# Altium schematic sheet 08_HALF_BRIDGE.SchDoc(08_HALF_BRIDGE)
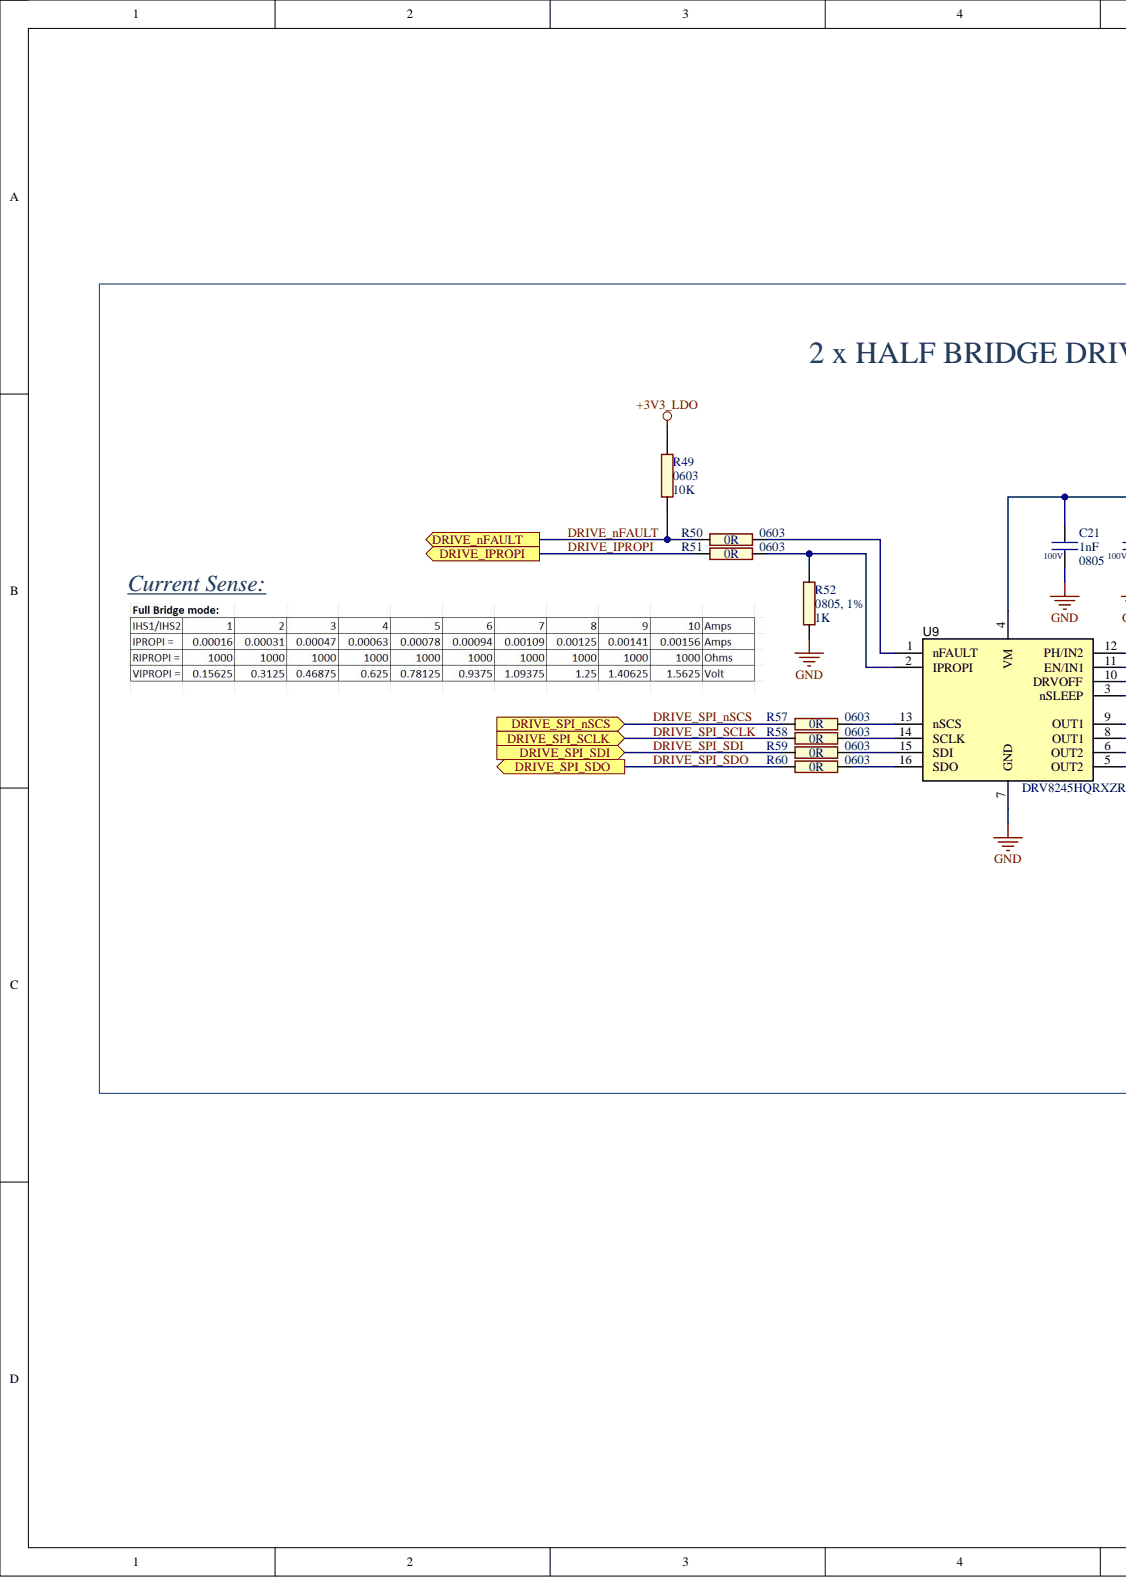
[diagram p0: 08_HALF_BRIDGE.SchDoc, left]
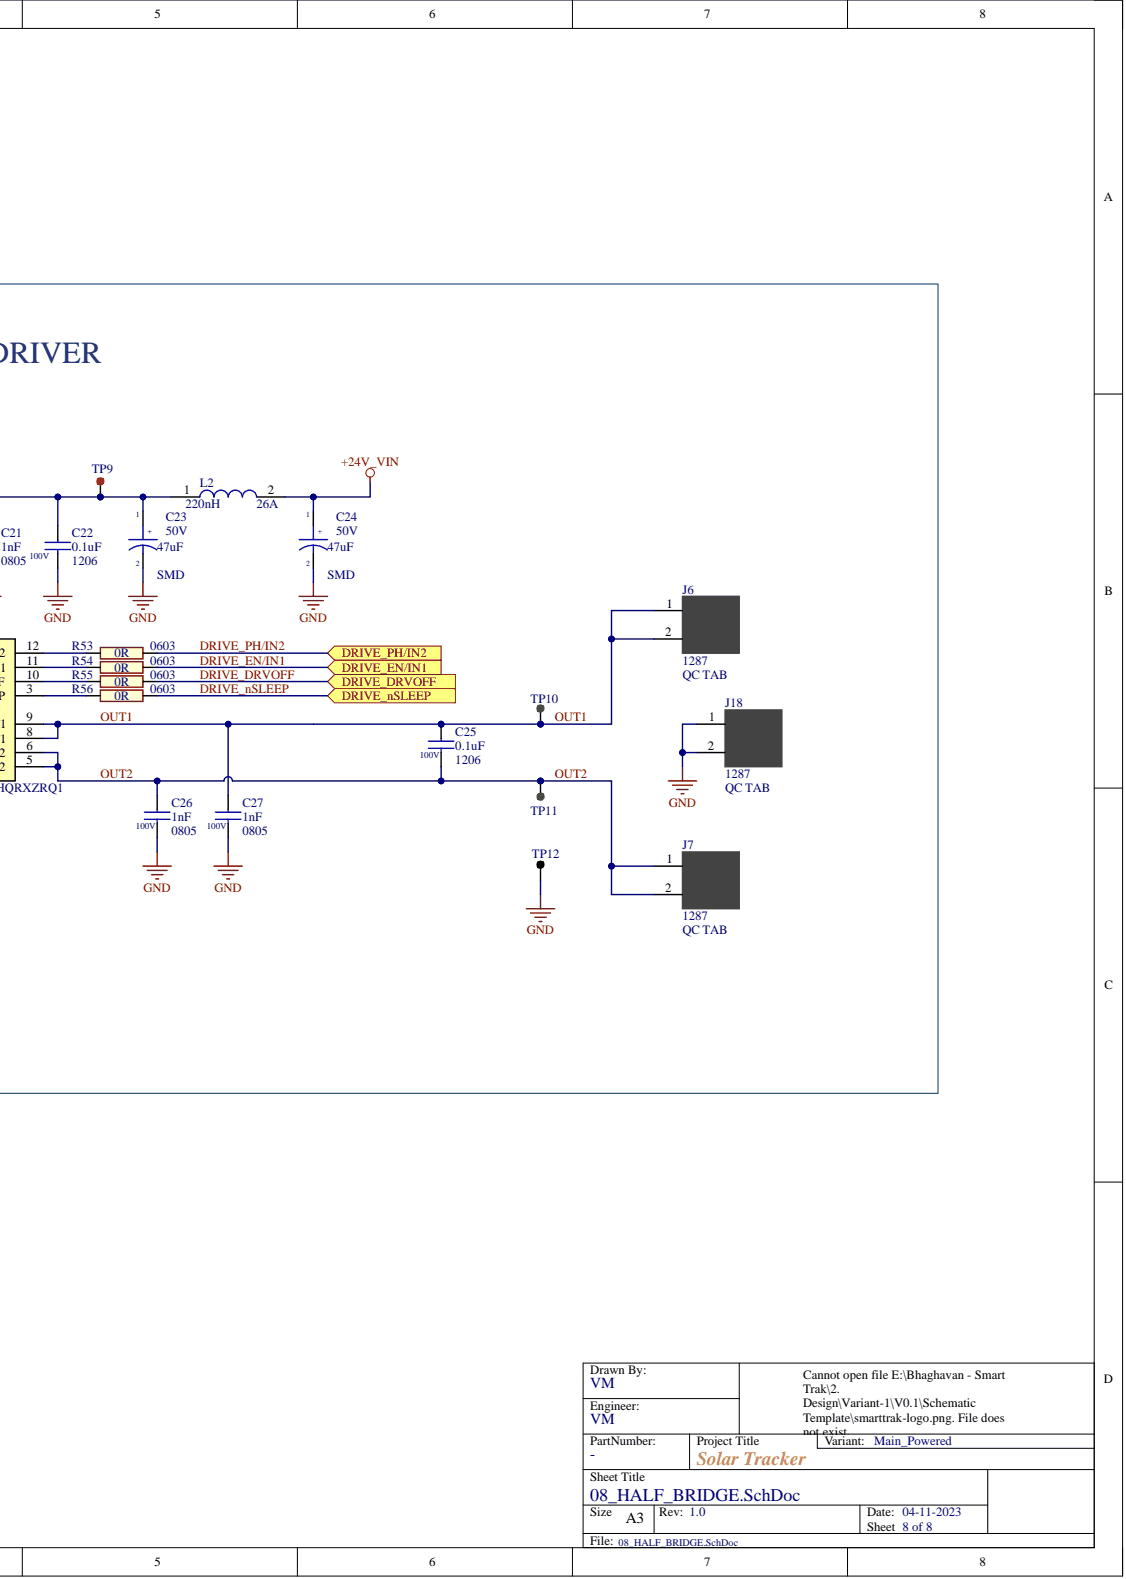
[diagram p1: 08_HALF_BRIDGE.SchDoc, right]

## Parts
C21  value 1nF  Note: 0805  Voltage - Rated: 100V  [C0805]  (hidden comment: CAP_1nF_0805_100V)
C22  value 0.1uF  Note: 1206  Voltage - Rated: 100V  [C1206]  (hidden comment: CAP_0.1uF_1206_100V)
C23  value 47uF  Note: SMD  Voltage - Rated: 50V  [CAPAE660X800N]  (hidden comment: CAP_ELEC_47uF_50V_SMD)
C24  value 47uF  Note: SMD  Voltage - Rated: 50V  [CAPAE660X800N]  (hidden comment: CAP_ELEC_47uF_50V_SMD)
C25  value 0.1uF  Note: 1206  Voltage - Rated: 100V  [C1206]  (hidden comment: CAP_0.1uF_1206_100V)
C26  value 1nF  Note: 0805  Voltage - Rated: 100V  [C0805]  (hidden comment: CAP_1nF_0805_100V)
C27  value 1nF  Note: 0805  Voltage - Rated: 100V  [C0805]  (hidden comment: CAP_1nF_0805_100V)
J6  QC_TAB_1287  value 1287  MPN: 1287  Note: QC TAB  [KEYSTONE_1287]
J7  QC_TAB_1287  value 1287  MPN: 1287  Note: QC TAB  [KEYSTONE_1287]
J18  QC_TAB_1287  value 1287  MPN: 1287  Note: QC TAB  [KEYSTONE_1287]
L2  value 220nH  Note: 26A  [IND_744307022]  (hidden comment: IND_220NH_26A_744307022)
R49  value 10K  Note: 0603  [R0603]  (hidden comment: RES_10K_0603)
R50  value 0R  Note: 0603  [R0603]  (hidden comment: RES_0R_0603)
R51  value 0R  Note: 0603  [R0603]  (hidden comment: RES_0R_0603)
R52  value 1K  Note: 0805, 1%  [R0805]  (hidden comment: RES_1K_0805)
R53  value 0R  Note: 0603  [R0603]  (hidden comment: RES_0R_0603)
R54  value 0R  Note: 0603  [R0603]  (hidden comment: RES_0R_0603)
R55  value 0R  Note: 0603  [R0603]  (hidden comment: RES_0R_0603)
R56  value 0R  Note: 0603  [R0603]  (hidden comment: RES_0R_0603)
R57  value 0R  Note: 0603  [R0603]  (hidden comment: RES_0R_0603)
R58  value 0R  Note: 0603  [R0603]  (hidden comment: RES_0R_0603)
R59  value 0R  Note: 0603  [R0603]  (hidden comment: RES_0R_0603)
R60  value 0R  Note: 0603  [R0603]  (hidden comment: RES_0R_0603)
TP9  TP_RED_5005  [KEYSTONE_5005]  (value not drawn)
TP10  TP_GREEN_5121  [KEYSTONE_5121]  (value not drawn)
TP11  TP_GREEN_5121  [KEYSTONE_5121]  (value not drawn)
TP12  TP_BLACK_5006  [KEYSTONE_5006]  (value not drawn)
U9  value DRV8245HQRXZRQ1  MPN: DRV8245HQRXZRQ1  [QFN_DRV8245HQRXZRQ1]  (hidden comment: DRV8245S-Q1-VQFN-HR-16)

## Ports
DRIVE_DRVOFF, DRIVE_EN/IN1, DRIVE_IPROPI, DRIVE_nFAULT, DRIVE_nSLEEP, DRIVE_PH/IN2, DRIVE_SPI_nSCS, DRIVE_SPI_SCLK, DRIVE_SPI_SDI, DRIVE_SPI_SDO

## Nets
+3V3_LDO: R49.2
+24V_VIN: C24.1 L2.2
GND: C21.2 C22.2 C23.2 C24.2 C26.2 C27.2 J18.1 J18.2 R52.1 TP12.1 U9.7(GND)
DRIVE_DRVOFF: R55.2 ; port DRIVE_DRVOFF
DRIVE_EN/IN1: R54.2 ; port DRIVE_EN/IN1
DRIVE_IPROPI: R51.1 ; port DRIVE_IPROPI
DRIVE_nFAULT: R49.1 R50.1 ; port DRIVE_nFAULT
DRIVE_nSLEEP: R56.2 ; port DRIVE_nSLEEP
DRIVE_PH/IN2: R53.2 ; port DRIVE_PH/IN2
DRIVE_SPI_nSCS: R57.1 ; port DRIVE_SPI_nSCS
DRIVE_SPI_SCLK: R58.1 ; port DRIVE_SPI_SCLK
DRIVE_SPI_SDI: R59.1 ; port DRIVE_SPI_SDI
DRIVE_SPI_SDO: R60.1 ; port DRIVE_SPI_SDO
OUT1: C25.1 C27.1 J6.1 J6.2 TP10.1 U9.8(OUT1) U9.9(OUT1)
OUT2: C25.2 C26.1 J7.1 J7.2 TP11.1 U9.5(OUT2) U9.6(OUT2)
NetC21_1: C21.1 C22.1 C23.1 L2.1 TP9.1 U9.4(VM)
NetR50_2: R50.2 U9.1(nFAULT)
NetR51_2: R51.2 R52.2 U9.2(IPROPI)
NetR53_1: R53.1 U9.12(PH/IN2)
NetR54_1: R54.1 U9.11(EN/IN1)
NetR55_1: R55.1 U9.10(DRVOFF)
NetR56_1: R56.1 U9.3(nSLEEP)
NetR57_2: R57.2 U9.13(nSCS)
NetR58_2: R58.2 U9.14(SCLK)
NetR59_2: R59.2 U9.15(SDI)
NetR60_2: R60.2 U9.16(SDO)

## Library data of the parts
C21 C26 C27 = CAP_1nF_0805_100V: CAP CER 1000PF 100V C0G 0805; Manufacturer: TDK; MPN: C2012C0G2A102J060AA; Component Kind: Standard; Library Name: Project.SchLib
C22 C25 = CAP_0.1uF_1206_100V: CAP CER 0.1UF 100V X7R 1206; Manufacturer: TDK Corporation; MPN: C3216X7R2A104K160AA; Component Kind: Standard; Library Name: Project.SchLib
C23 C24 = CAP_ELEC_47uF_50V_SMD: CAP ALUM 47UF 20% 50V SMD; Manufacturer: Panasonic; MPN: EEE-FK1H470XP; Component Kind: Standard; Library Name: Project.SchLib
J6 J7 J18 = QC_TAB_1287: CONN QC TAB 0.250 SOLDER; Manufacturer: Keystone Electronics; Component Kind: Standard; Library Name: Project.SchLib
L2 = IND_220NH_26A_744307022: FIXED IND 220NH 26A 0.29mOHM; Manufacturer: Würth Elektronik; Component Kind: Standard; Library Name: Project.SchLib; MPN: 744307022
R49 = RES_10K_0603: RES 10K OHM 5% 1/10W 0603; Manufacturer: Yageo; MPN: RC0603JR-0710KL; Component Kind: Standard; Library Name: Project.SchLib
R50 R51 R53-R60 = RES_0R_0603: RES SMD 0 OHM JUMPER 1/10W 0603; Manufacturer: Yageo; MPN: RC0603JR-070RL; Component Kind: Standard; Library Name: Project.SchLib
R52 = RES_1K_0805: RES SMD 1K OHM 1% 1/2W 0805; Manufacturer: Panasonic; MPN: ERJ-P06F1001V; Component Kind: Standard; Library Name: Project.SchLib
TP9 = TP_RED_5005: PC TEST POINT COMPACT RED; Manufacturer: Keystone Electronics; MPN: 5005; Value: 5005; Component Kind: Standard; Library Name: Project.SchLib; Note: -
TP10 TP11 = TP_GREEN_5121: PC TEST POINT COMPACT GREEN; Manufacturer: Keystone Electronics; MPN: 5121; Value: 5121; Component Kind: Standard; Library Name: Project.SchLib; Note: -
TP12 = TP_BLACK_5006: PC TEST POINT COMPACT BLACK; Manufacturer: Keystone Electronics; MPN: 5006; Value: 5006; Component Kind: Standard; Library Name: Project.SchLib; Note: -
U9 = DRV8245S-Q1-VQFN-HR-16: AUTOMOTIVE 40-V, 32-A H-BRIDGE DRIVER  PWM, SPI 16-VQFN; Manufacturer: Texas Instruments; Component Kind: Standard; ComponentLink1Description: Datasheet Link; Library Name: Project.SchLib
